# Altium schematic sheet AS06-01TB-V4.0.SchDoc
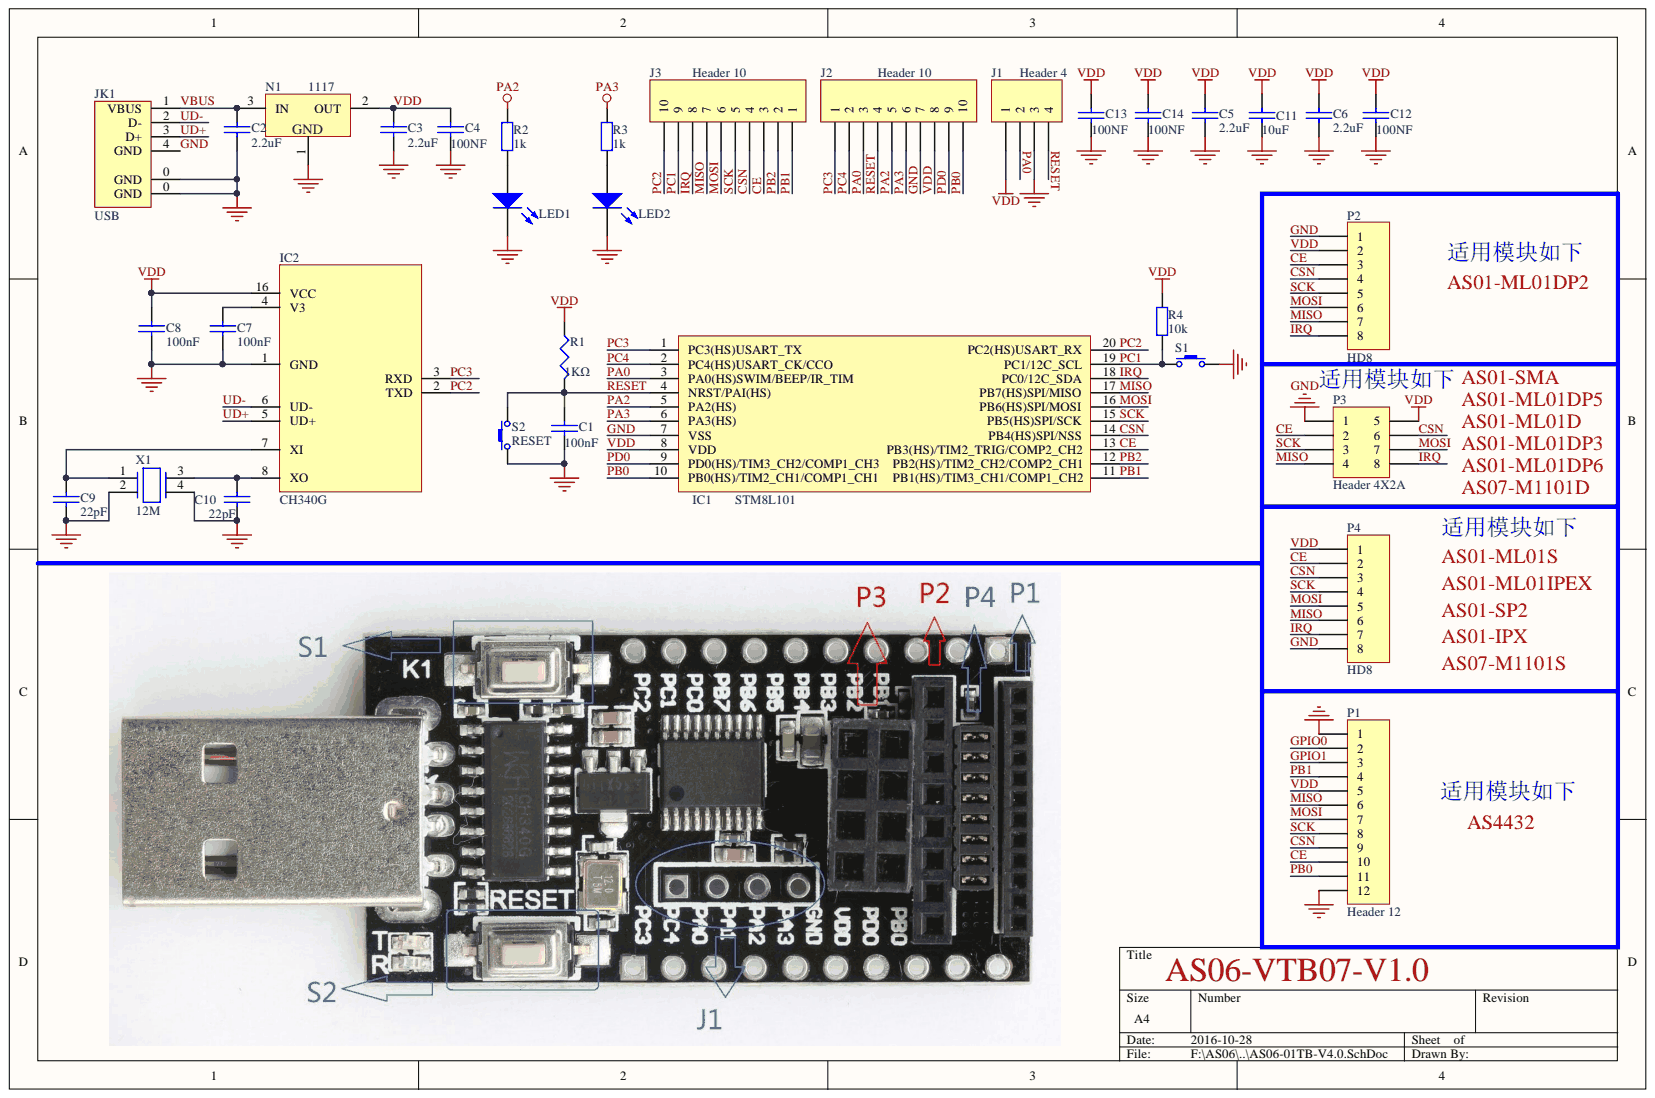
[diagram p0: AS06-01TB-V4.0.SchDoc]

## Parts
C1  value 100nF  [SMD-0402-C]
C2  2.2uF  [SMD-0603-C]
C3  2.2uF  [SMD-0603-C]
C4  100NF  [SMD-0402-C]
C5  value 2.2uF  [SMD-0603-C]
C6  value 2.2uF  [SMD-0603-C]
C7  value 100nF  [SMD-0402-C]
C8  value 100nF  [SMD-0402-C]
C9  value 22pF  [SMD-0402-C]
C10  value 22pF  [SMD-0402-C]
C11  value 10uF  [R-SMD-0805]
C12  100NF  [SMD-0402-C]
C13  100NF  [SMD-0402-C]
C14  100NF  [SMD-0402-C]
IC1  STM8L101  [IC-TSSOP20]
IC2  CH340G  [IC-NSOIC16]
J1  Header 4  [HDR1X4]
J2  Header 10  [HDR1X10]
J3  Header 10  [HDR1X10]
JK1  USB  [USB]
LED1  LED0603  [LED0603]  (value not drawn)
LED2  LED0603  [LED0603]  (value not drawn)
N1  1117  [SOT-89-3L]
P1  Header 12  [HEDER1.27*12]
P2  HD8  [Header2.0*8)]
P3  Header 4X2A  [HDR2X4_CEN]
P4  HD8  [HD8-1.27]
R1  value 1KΩ  [SMD-0402-R]
R2  value 1k  [SMD-0402-R]  (hidden comment: RES2-0603/SMD)
R3  value 1k  [SMD-0402-R]  (hidden comment: RES2-0603/SMD)
R4  value 10k  [SMD-0402-R]  (hidden comment: RES2-0603/SMD)
S1  RST  [KEY3]  (value not drawn)
S2  RESET  [KEY3]
X1  12M  [crystal-3225]

## Nets
CE: IC1.13(PB3(HS)/TIM2_TRIG/COMP2_CH2) J3.3 P1.10 P2.3 P3.2 P4.2
CSN: IC1.14(PB4(HS)SPI/NSS) J3.4 P1.9 P2.4 P3.6 P4.3
GND: C1.2 C2.2 C3.2 C4.2 C5.1 C6.1 C7.1 C8.1 C9.1 C10.1 C11.1 C12.2 C13.2 C14.2 IC1.7(VSS) IC2.1(GND) J1.3 J2.7 JK1.0(GND) JK1.4(GND) LED1.2 LED2.2 N1.1 P1.1 P1.12 P2.1 P3.1 P4.8 S1.2 S2.1 X1.2 X1.4
GPIO0: P1.2
GPIO1: P1.3
IRQ: IC1.18(PC0/12C_SDA) J3.8 P2.8 P3.8 P4.7
MISO: IC1.17(PB7(HS)SPI/MISO) J3.7 P1.6 P2.7 P3.4 P4.6
MOSI: IC1.16(PB6(HS)SPI/MOSI) J3.6 P1.7 P2.6 P3.7 P4.5
PA0: IC1.3(PA0(HS)SWIM/BEEP/IR_TIM) J1.2 J2.3
PA2: IC1.5(PA2(HS)) J2.5 R2.2
PA3: IC1.6(PA3(HS)) J2.6 R3.2
PB0: IC1.10(PB0(HS)/TIM2_CH1/COMP1_CH1) J2.10 P1.11
PB1: IC1.11(PB1(HS)/TIM3_CH1/COMP1_CH2) J3.1 P1.4
PB2: IC1.12(PB2(HS)/TIM2_CH2/COMP2_CH1) J3.2
PC1: IC1.19(PC1/12C_SCL) J3.9 R4.1 S1.1
PC2: IC1.20(PC2(HS)USART_RX) IC2.2(TXD) J3.10
PC3: IC1.1(PC3(HS)USART_TX) IC2.3(RXD) J2.1
PC4: IC1.2(PC4(HS)USART_CK/CCO) J2.2
PD0: IC1.9(PD0(HS)/TIM3_CH2/COMP1_CH3) J2.9
RESET: C1.1 IC1.4(NRST/PAI(HS)) J1.4 J2.4 R1.2 S2.2
SCK: IC1.15(PB5(HS)SPI/SCK) J3.5 P1.8 P2.5 P3.3 P4.4
UD+: IC2.5(UD+) JK1.3(D+)
UD-: IC2.6(UD-) JK1.2(D-)
VBUS: C2.1 JK1.1(VBUS) N1.3
VDD: C3.1 C4.1 C5.2 C6.2 C8.2 C11.2 C12.1 C13.1 C14.1 IC1.8(VDD) IC2.16(VCC) J1.1 J2.8 N1.2 P1.5 P2.2 P3.5 P4.1 R1.1 R4.2
NetC7_2: C7.2 IC2.4(V3)
NetC9_2: C9.2 IC2.7(XI) X1.1
NetC10_2: C10.2 IC2.8(XO) X1.3
NetLED1_1: LED1.1 R2.1
NetLED2_1: LED2.1 R3.1

## Library data of the parts
C1-C4 C12-C14 = CAP: Component Kind: Standard
C5-C11 = CAP: Capacitor; Component Kind: Standard
J1 = Header 4: Header, 4-Pin; Component Kind: Standard; LatestRevisionDate: 17-Jul-2002; LatestRevisionNote: Re-released for DXP Platform.; Library Name: Miscellaneous Connectors.IntLib; Signal Integrity: Connector; Publisher: Altium Limited
J2 J3 = Header 10: Header, 10-Pin; Component Kind: Standard; LatestRevisionDate: 17-Jul-2002; LatestRevisionNote: Re-released for DXP Platform.; Library Name: Miscellaneous Connectors.IntLib; Signal Integrity: Connector; Publisher: Altium Limited
P1 = Header 12: Header, 12-Pin; Component Kind: Standard; LatestRevisionDate: 17-Jul-2002; LatestRevisionNote: Re-released for DXP Platform.; Library Name: Miscellaneous Connectors.IntLib; Signal Integrity: Connector; Publisher: Altium Limited
P3 = Header 4X2A: Header, 4-Pin, Dual row; Component Kind: Standard; LatestRevisionDate: 17-Jul-2002; LatestRevisionNote: Re-released for DXP Platform.; Library Name: Miscellaneous Connectors.IntLib; Signal Integrity: Connector; Publisher: Altium Limited
R1 = RES1: Component Kind: Standard; Library Name: AS06_STM8_V1.0.SCHLIB
S1 S2 = KEY4: Component Kind: Standard; Library Name: 常用库.SCHLIB
X1 = XTAL4: Component Kind: Standard; Library Name: 原理图库.SchLib
IC1 = STM8L101: Component Kind: Standard; Library Name: AS06_STM8_V1.0.SCHLIB
IC2 = CH340G: Component Kind: Standard; Library Name: 原理图库.SchLib
JK1 = USB: Component Kind: Standard; Library Name: 集成库.IntLib
LED1 LED2 = LED0603: Component Kind: Standard; Library Name: 集成库.IntLib
N1 = 1117: Component Kind: Standard; Library Name: 原理图库.SchLib
P2 P4 = HD8: Component Kind: Standard; Library Name: 原理图库.SchLib
R2-R4 = RES2-0603/SMD: Component Kind: Standard; Library Name: 集成库.IntLib
